# Altium schematic sheet LED connector.SchDoc("LED connector")
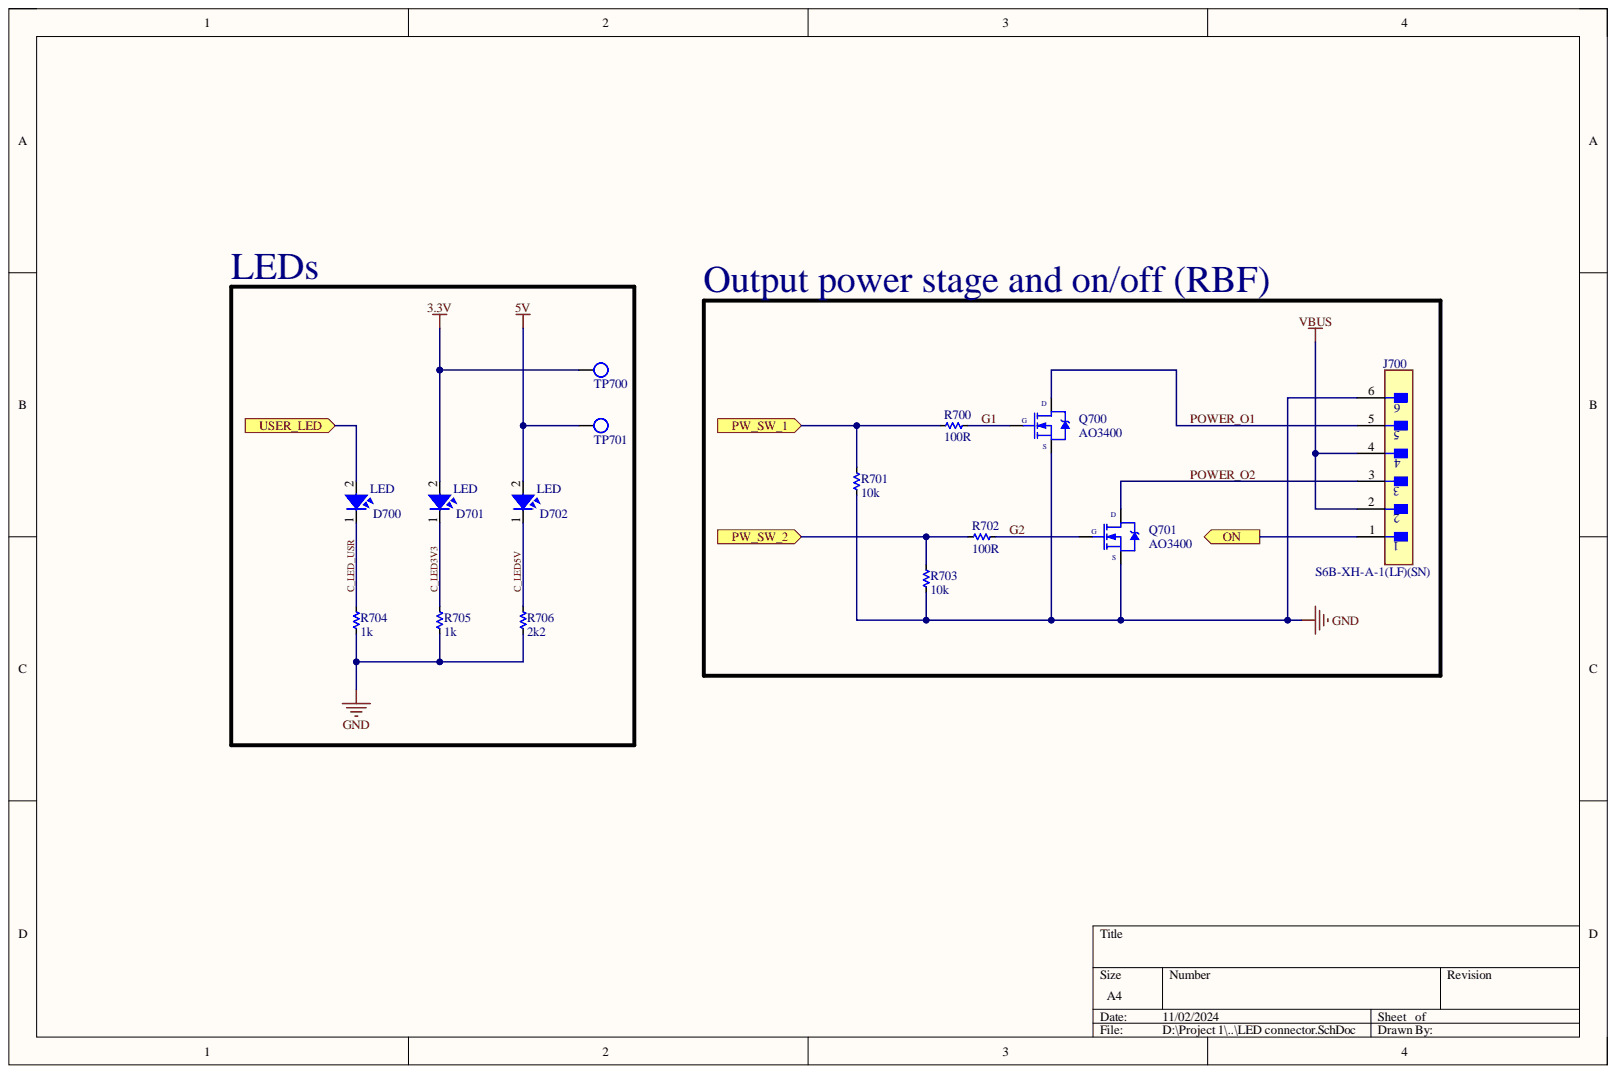
[diagram p0: LED connector.SchDoc]

## Parts
D700  LED  [LED-0805-Green]
D701  LED  [LED-0805-Green]
D702  LED  [LED-0805-Green]
J700  S6B-XH-A-1(LF)(SN)  [FP-S6B-XH-A-1_LF_SN-MFG]
Q700  AO3400  [SOT23-123]
Q701  AO3400  [SOT23-123]
R700  100R  [RES-SMD-0603]
R701  10k  [RES-SMD-0603]
R702  100R  [RES-SMD-0603]
R703  10k  [RES-SMD-0603]
R704  1k  [RES-SMD-0603]
R705  1k  [RES-SMD-0603]
R706  2k2  [RES-SMD-0603]
TP700  Test-Point  [TESTPOINT]  (value not drawn)
TP701  Test-Point  [TESTPOINT]  (value not drawn)

## Ports
ON, PW_SW_1, PW_SW_2, USER_LED

## Nets
3.3V: D701.1 TP700.1
5V: D702.1 TP701.1
GND: J700.6 Q700.3 Q701.3 R701.2 R703.2 R704.2 R705.2 R706.2
VBUS: J700.2 J700.4
C_LED3V3: D701.2 R705.1
C_LED5V: D702.2 R706.1
C_LED_USR: D700.2 R704.1
G1: Q700.1 R700.1
G2: Q701.1 R702.1
ON: J700.1 ; port ON
POWER_O1: J700.5 Q700.2
POWER_O2: J700.3 Q701.2
PW_SW_1: R700.2 R701.1 ; port PW_SW_1
PW_SW_2: R702.2 R703.1 ; port PW_SW_2
USER_LED: D700.1 ; port USER_LED

## Library data of the parts
D700-D702 = LED SMD: Value: LED 3 - 5 ly 2 Colour; Component Kind: Standard; Library Name: Library.SchLib
J700 = CMP-17439-000023-1: CONN HEADER R/A P6OS 2.5MM; Current Rating: 3A; Gender: Male; Insulation Resistance: 1GR; Number of Positions: 6; Voltage Rating: 250V; Color: Natural; Component Kind: Standard; Contact Material: Brass; Contact Plating: Tin; Lead Free: Lead Free; Library Name: Altium Content Vault; Material: Polyamide; Max Operating Temperature: 85°C; Min Operating Temperature: -25°C; Mount: Through Hole; Number of Rows: 1; Pitch: 2.5mm; Termination: Crimp
Q700 Q701 = AO3400: N-MOS 30V 5.8A; Component Kind: Standard; Library Name: Library.SchLib
R700-R706 = Res-SMD: Resistor; Manufacturer: Generic Components; Class: Passive; Component Kind: Standard; Library Name: Library.SchLib; Published: 08/06/2000; Publisher: Altium Hobart Technology Centre
TP700 TP701 = Test-Point: Component Kind: Standard; Library Name: Library.SchLib
